# Altium schematic sheet USB_BREAKOUTS_CCU_HAS.SchDoc
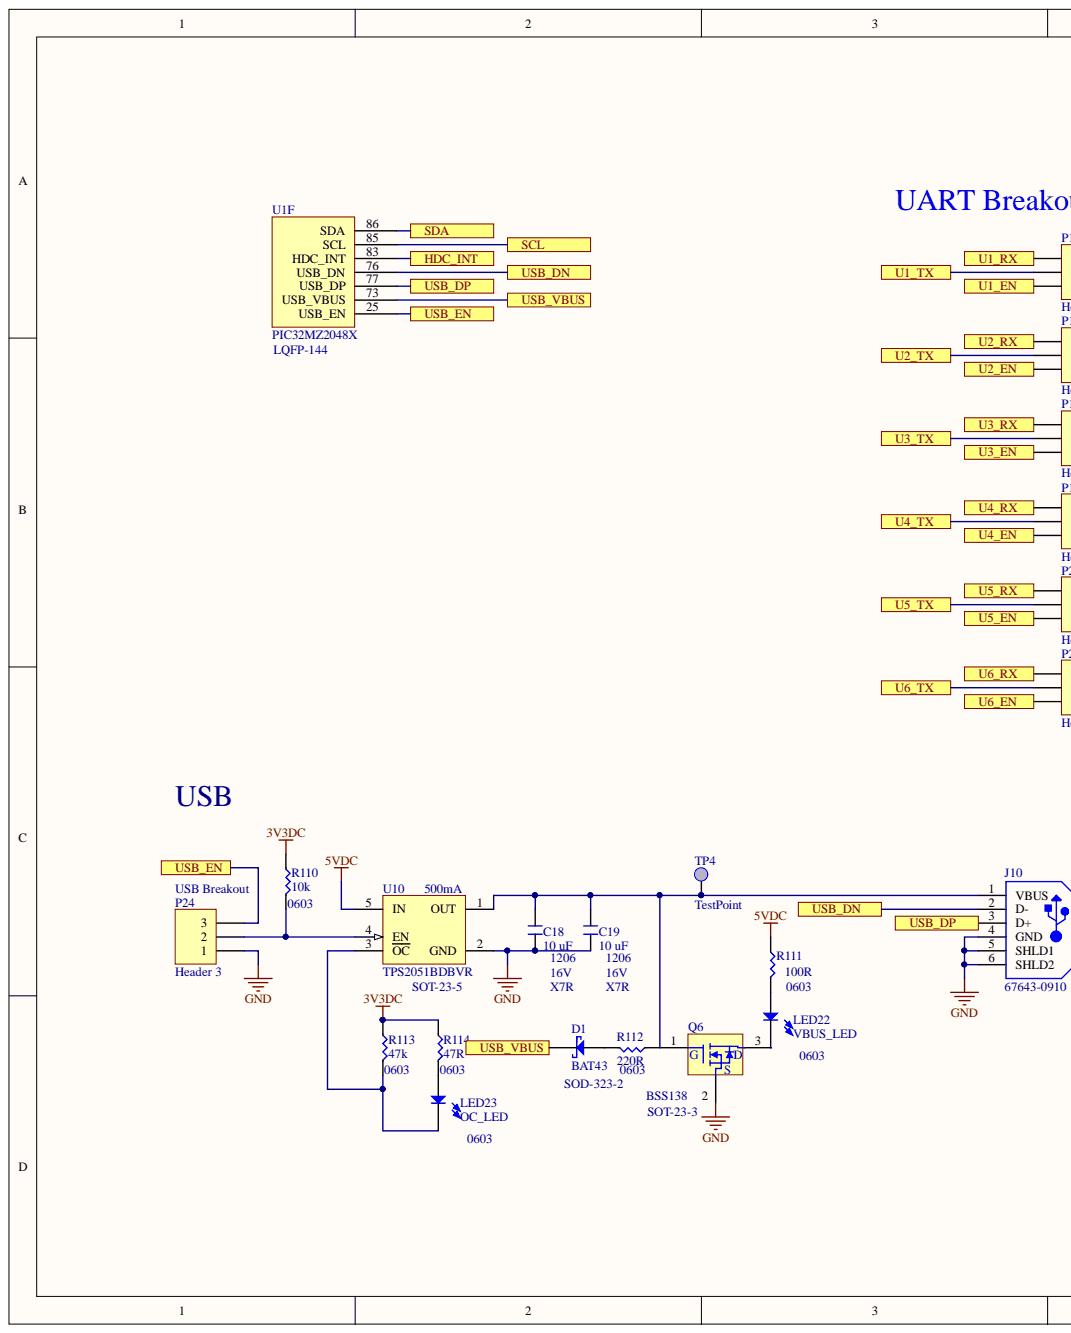
[diagram p0: USB_BREAKOUTS_CCU_HAS.SchDoc, left]
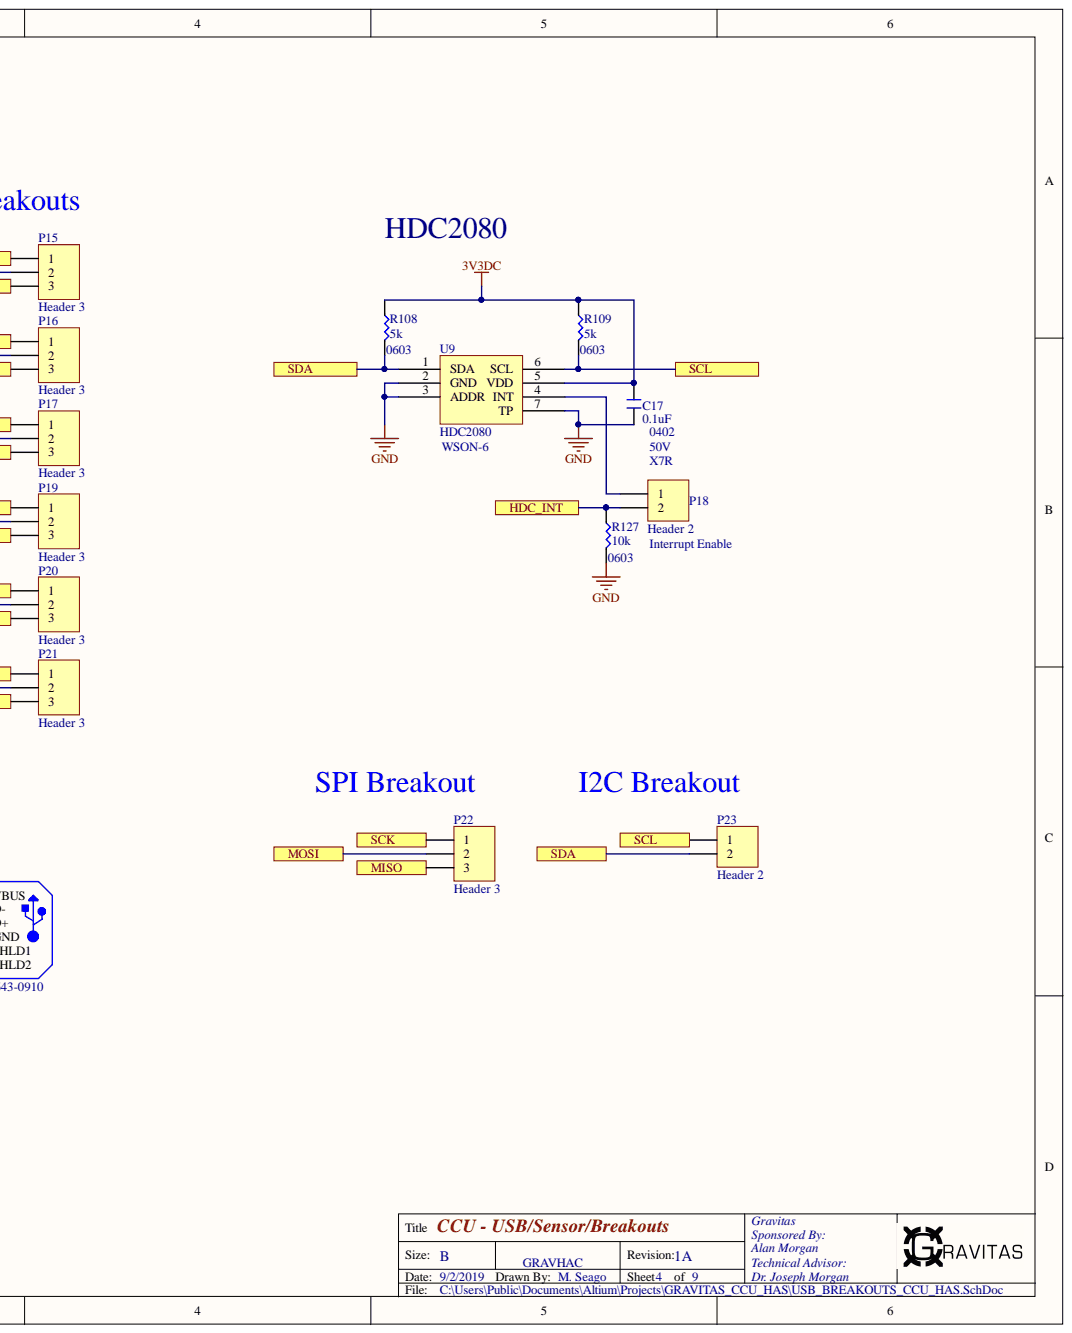
[diagram p1: USB_BREAKOUTS_CCU_HAS.SchDoc, right]

## Parts
C17  0.1uF  [NonPolar-0402]
C18  10 uF  [NONPOLAR-1206]
C19  10 uF  [NONPOLAR-1206]
D1  BAT43  [BAT43WS-E3-08]
J10  67643-0910  [TE-292303-1_V]
LED22  VBUS_LED  [LED-0603]
LED23  OC_LED  [LED-0603]
P15  Header 3  [HDR1X3]
P16  Header 3  [HDR1X3]
P17  Header 3  [HDR1X3]
P18  Header 2  [HDR1X2]
P19  Header 3  [HDR1X3]
P20  Header 3  [HDR1X3]
P21  Header 3  [HDR1X3]
P22  Header 3  [HDR1X3]
P23  Header 2  [HDR1X2]
P24  Header 3  [HDR1X3]
Q6  BSS138  [BSS138-SOT23]
R108  5k  [NonPolar-0603]
R109  5k  [NonPolar-0603]
R110  10k  [NonPolar-0603]
R111  100R  [NonPolar-0603]
R112  220R  [NonPolar-0603]
R113  47k  [NonPolar-0603]
R114  47R  [NonPolar-0603]
R127  10k  [NonPolar-0603]
TP4  TestPoint  [TP - S2751-46R]
U1  PIC32MZ2048X  [PIC32MZ-FlatPack-144 Pin]  (value not drawn)  (part F)
U9  HDC2080  [HDC2080]
U10  TPS2051BDBVR  Output Current: 500mA  Package / Case: SOT-23-5  [DBV0005A_L]

## Ports
HDC_INT, MISO, MOSI, SCK, SCL, SDA, U1_EN, U1_RX, U1_TX, U2_EN, U2_RX, U2_TX, U3_EN, U3_RX, U3_TX, U4_EN, U4_RX, U4_TX, U5_EN, U5_RX, U5_TX, U6_EN, U6_RX, U6_TX, USB_DN, USB_DP, USB_EN, USB_VBUS

## Nets
3V3DC: C17.2 R108.2 R109.2 R110.2 R113.2 R114.2 U9.5(VDD)
5VDC: R111.2 U10.5(IN)
GND: C17.1 C18.1 C19.1 J10.4(GND) J10.5(SHLD1) J10.6(SHLD2) P24.1 Q6.2 R127.1 U9.2(GND) U9.3(ADDR) U9.7(TP) U10.2(GND)
HDC_INT: P18.2 R127.2 U1.83(HDC_INT) ; port HDC_INT
MISO: P22.3 ; port MISO
MOSI: P22.2 ; port MOSI
SCK: P22.1 ; port SCK
SCL: P23.1 R109.1 U1.85(SCL) U9.6(SCL) ; port SCL
SDA: P23.2 R108.1 U1.86(SDA) U9.1(SDA) ; port SDA
U1_EN: P15.3 ; port U1_EN
U1_RX: P15.1 ; port U1_RX
U1_TX: P15.2 ; port U1_TX
U2_EN: P16.3 ; port U2_EN
U2_RX: P16.1 ; port U2_RX
U2_TX: P16.2 ; port U2_TX
U3_EN: P17.3 ; port U3_EN
U3_RX: P17.1 ; port U3_RX
U3_TX: P17.2 ; port U3_TX
U4_EN: P19.3 ; port U4_EN
U4_RX: P19.1 ; port U4_RX
U4_TX: P19.2 ; port U4_TX
U5_EN: P20.3 ; port U5_EN
U5_RX: P20.1 ; port U5_RX
U5_TX: P20.2 ; port U5_TX
U6_EN: P21.3 ; port U6_EN
U6_RX: P21.1 ; port U6_RX
U6_TX: P21.2 ; port U6_TX
USB_DN: J10.2(D-) U1.76(USB_DN) ; port USB_DN
USB_DP: J10.3(D+) U1.77(USB_DP) ; port USB_DP
USB_EN: P24.3 U1.25(USB_EN) ; port USB_EN
USB_VBUS: D1.1 U1.73(USB_VBUS) ; port USB_VBUS
NetC18_2: C18.2 C19.2 J10.1(VBUS) R112.2 TP4.1 U10.1(OUT)
NetD1_2: D1.2 R112.1
NetLED22_1: LED22.1 R111.1
NetLED22_2: LED22.2 Q6.3
NetLED23_1: LED23.1 R114.1
NetLED23_2: LED23.2 R113.1 U10.3(OC)
NetP18_1: P18.1 U9.4(INT)
NetP24_2: P24.2 R110.1 U10.4(EN)

Not connected: Q6 1

## Library data of the parts
C17 = Cap - 0402: Component Kind: Standard; Library Name: GravLib.IntLib
C18 C19 = Cap - 1206: CGA5L1X7R1E106K160AE; Component Kind: Standard; Library Name: GravLib.IntLib
D1 = BAT43: BAT43WS-E3-08; Component Kind: Standard; Library Name: GravLib.IntLib
J10 = CMP-2000-05404-1: 1 Port Right Angle Through Hole Mount Female Type A USB Connector, 4 Position, -55 to 85 degC, RoHS, Tube; Manufacturer: TE Connectivity; Connector Mounting: PCB; Connector Type: USBA; Contact Termination Type: Solder; Gender: Receptacle; No. of Contacts: 4; SVHC: NoSVHC(16-Jun-2014); Component Kind: Standard; Contact Material: Copper; Contact Plating: Gold; Datasheet URL: http://www.farnell.com/cad/1653030.pdf; Datasheet Version: Feb-12; Library Name: Altium Content Vault; Manufacturer URL: http://www.te.com/; Package Description: 4-Pin Through Hole Device, Body 14 x 13.13 mm, Pitch 2.5 mm; Package Reference: 292303-1
LED22 LED23 = LED-0603: Component Kind: Standard; Library Name: GravLib.IntLib
P15-P17 P19-P22 P24 = Header 3: Header, 3-Pin; Component Kind: Standard; LatestRevisionDate: 17-Jul-2002; LatestRevisionNote: Re-released for DXP Platform.; Library Name: Miscellaneous Connectors.IntLib; Signal Integrity: Connector; Publisher: Altium Limited
P18 P23 = Header 2: Header, 2-Pin; Component Kind: Standard; LatestRevisionDate: 17-Jul-2002; LatestRevisionNote: Re-released for DXP Platform.; Library Name: Miscellaneous Connectors.IntLib; Signal Integrity: Connector; Publisher: Altium Limited
R108-R114 R127 = Res - 0603: Component Kind: Standard; Library Name: GravLib.IntLib
TP4 = TestPoint: S2751-46R; Component Kind: Standard; Library Name: GravLib.IntLib
U10 = CMP-2000-07277-1: Current-Limited, Power-Distribution Switch, 2.7 to 5.5 V, -40 to 85 degC, 5-Pin SOT-23 (DBV), Green (RoHS & no Sb/Br), Tape and Reel; Brand: TexasInstruments; Current Limit: 1A; Development Kit: TPS2051BEVM; Number of Outputs: 1Output; On Resistance - Max: 70mOhms; Operating Supply Voltage: 2.7Vto5.5V; Package Version: 4073253-4/N, 12/2014; Supply Voltage - Max: 5.5V; Supply Voltage - Min: 2.7V; Component Kind: Standard; Datasheet URL: http://www.ti.com/general/docs/lit/getliterature.tsp?genericPartNumber=TPS2051B&fileType=pdf; Datasheet Version: Rev. L, 06/2011; Factory Pack Quantity: 3000; Library Name: Altium Content Vault; Manufacturer: TexasInstruments; Manufacturer URL: http://www.ti.com/; Maximum Operating Temperature: +85C; Minimum Operating Temperature: -40C; Mounting Style: SMD/SMT; Mounting Technology: SurfaceMount; Package Description: 5-Pin Small Outline Transistor, Body 2.9 x 1.6 mm, Pitch 0.95 mm; Package Reference: DBV5; Package URL: http://www.ti.com/lit/ml/mpds018l/mpds018l.pdf; Packaging: Reel; Product: USBCurrentLimitedPowerSwitches; Product Category: PowerSwitchICs-PowerDistribution; RoHS: Details; Series: TPS2051B; Type: HighSide
Q6 = BSS138: Component Kind: Standard; Library Name: GravLib.IntLib
U1 = PIC32MZ2048X: Component Kind: Standard; Library Name: GravLib.IntLib
U9 = HDC2080: Component Kind: Standard; Library Name: GravLib.IntLib
